# Altium schematic sheet VL53L1-V1.0.SchDoc
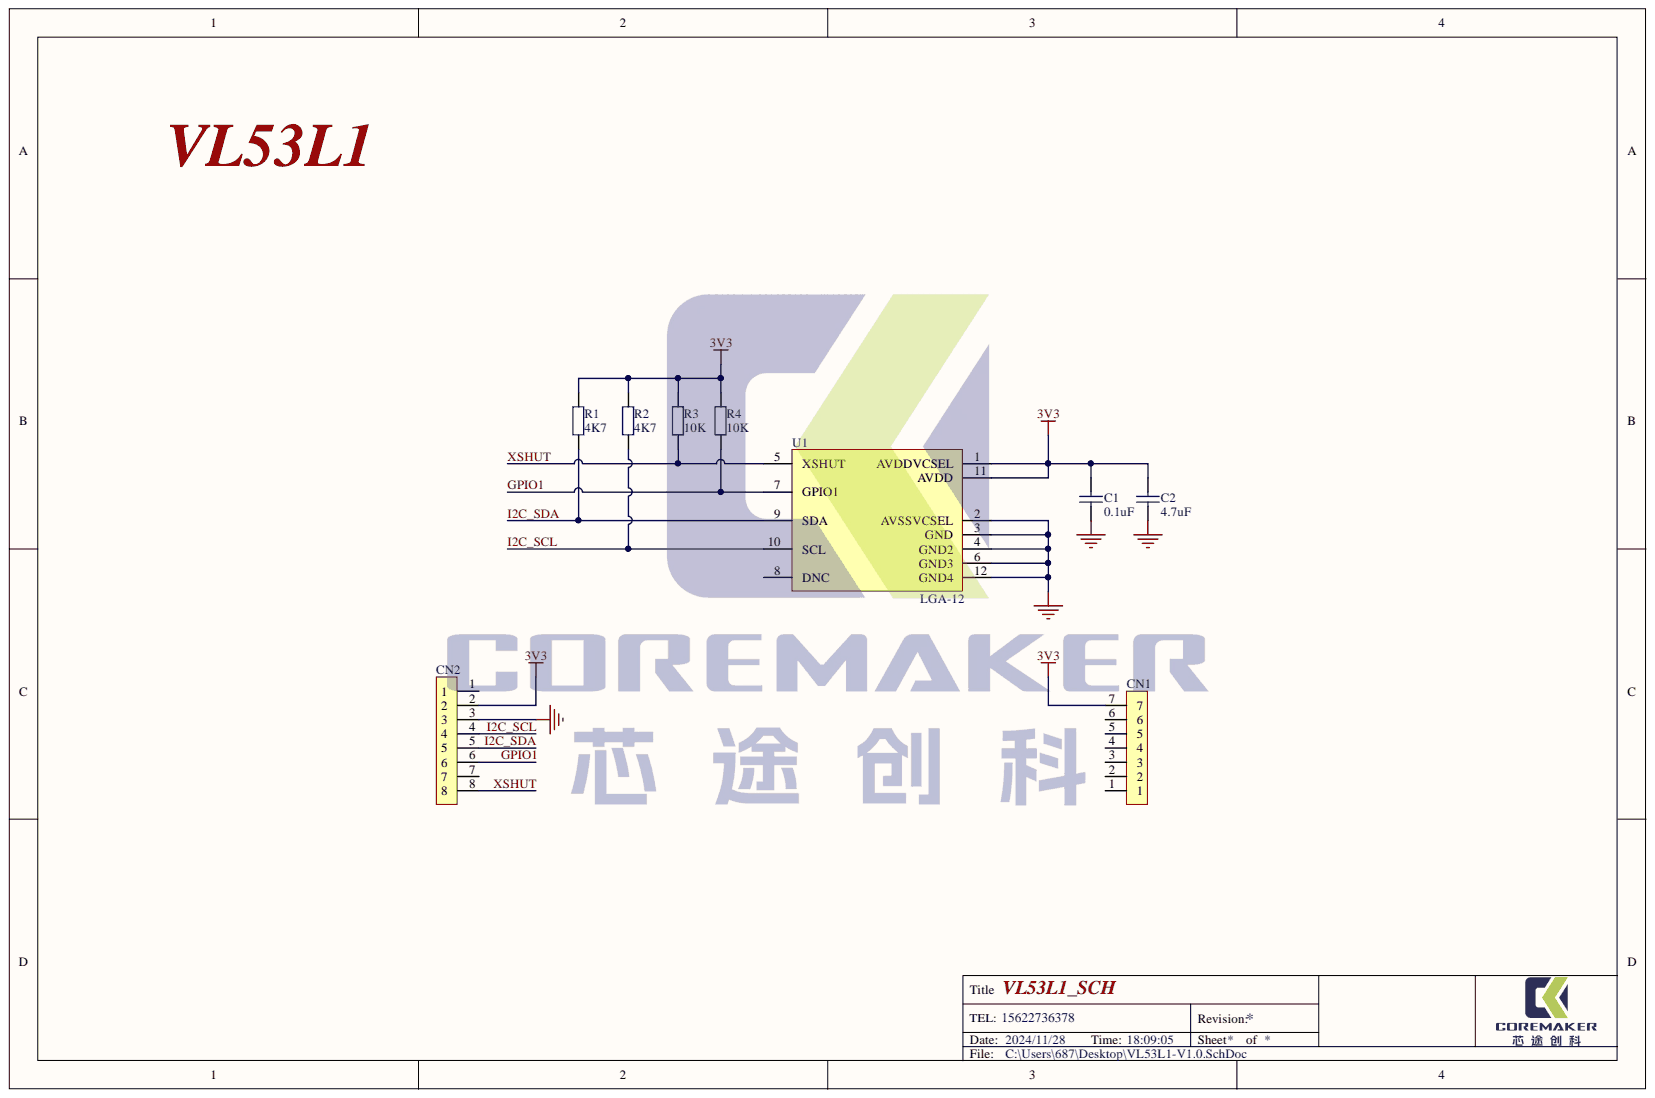
[diagram p0: VL53L1-V1.0.SchDoc]

## Parts
C1  0.1uF  [CAP_0603]
C2  4.7uF  [CAP_0603]
CN1  Header 7X1  [1X7PIN]  (value not drawn)
CN2  Header 8X1  [1X8PIN]  (value not drawn)
R1  4K7  [RES_0603]
R2  4K7  [RES_0603]
R3  10K  [RES_0603]
R4  10K  [RES_0603]
U1  LGA-12  [LGA-12]

## Nets
3V3: C1.1 C2.1 CN1.7 CN2.2 R1.2 R2.2 R3.2 R4.2 U1.1 U1.11
GND: C1.2 C2.2 CN2.3 U1.2 U1.3 U1.4 U1.6 U1.12
GPIO1: CN2.6 R4.1 U1.7
I2C_SCL: CN2.4 R2.1 U1.10
I2C_SDA: CN2.5 R1.1 U1.9
XSHUT: CN2.8 R3.1 U1.5

Not connected: CN1 1 2 3 4 5 6; CN2 1 7; U1 8

## Library data of the parts
C1 C2 = CAP: Component Kind: Standard; Description: CAP; Library Name: ST_2.4G.SchLib
R1-R4 = Res: Component Kind: Standard; Description: Res; Library Name: ST_2.4G.SchLib
U1 = VL53L4CD: Component Kind: Standard; Description: VL53L4CD; Library Name: 1107_G431_VL53L4CD.SchLib
CN1 = Header 7X1: Component Kind: Standard; Description: Header 7X1; Library Name: VL53L4_PCB.SchLib
CN2 = Header 8X1: Component Kind: Standard; Description: Header 8X1; Library Name: 1019_WB55_Senser.SchLib
